# Altium schematic sheet MCU.schdoc(MCU)
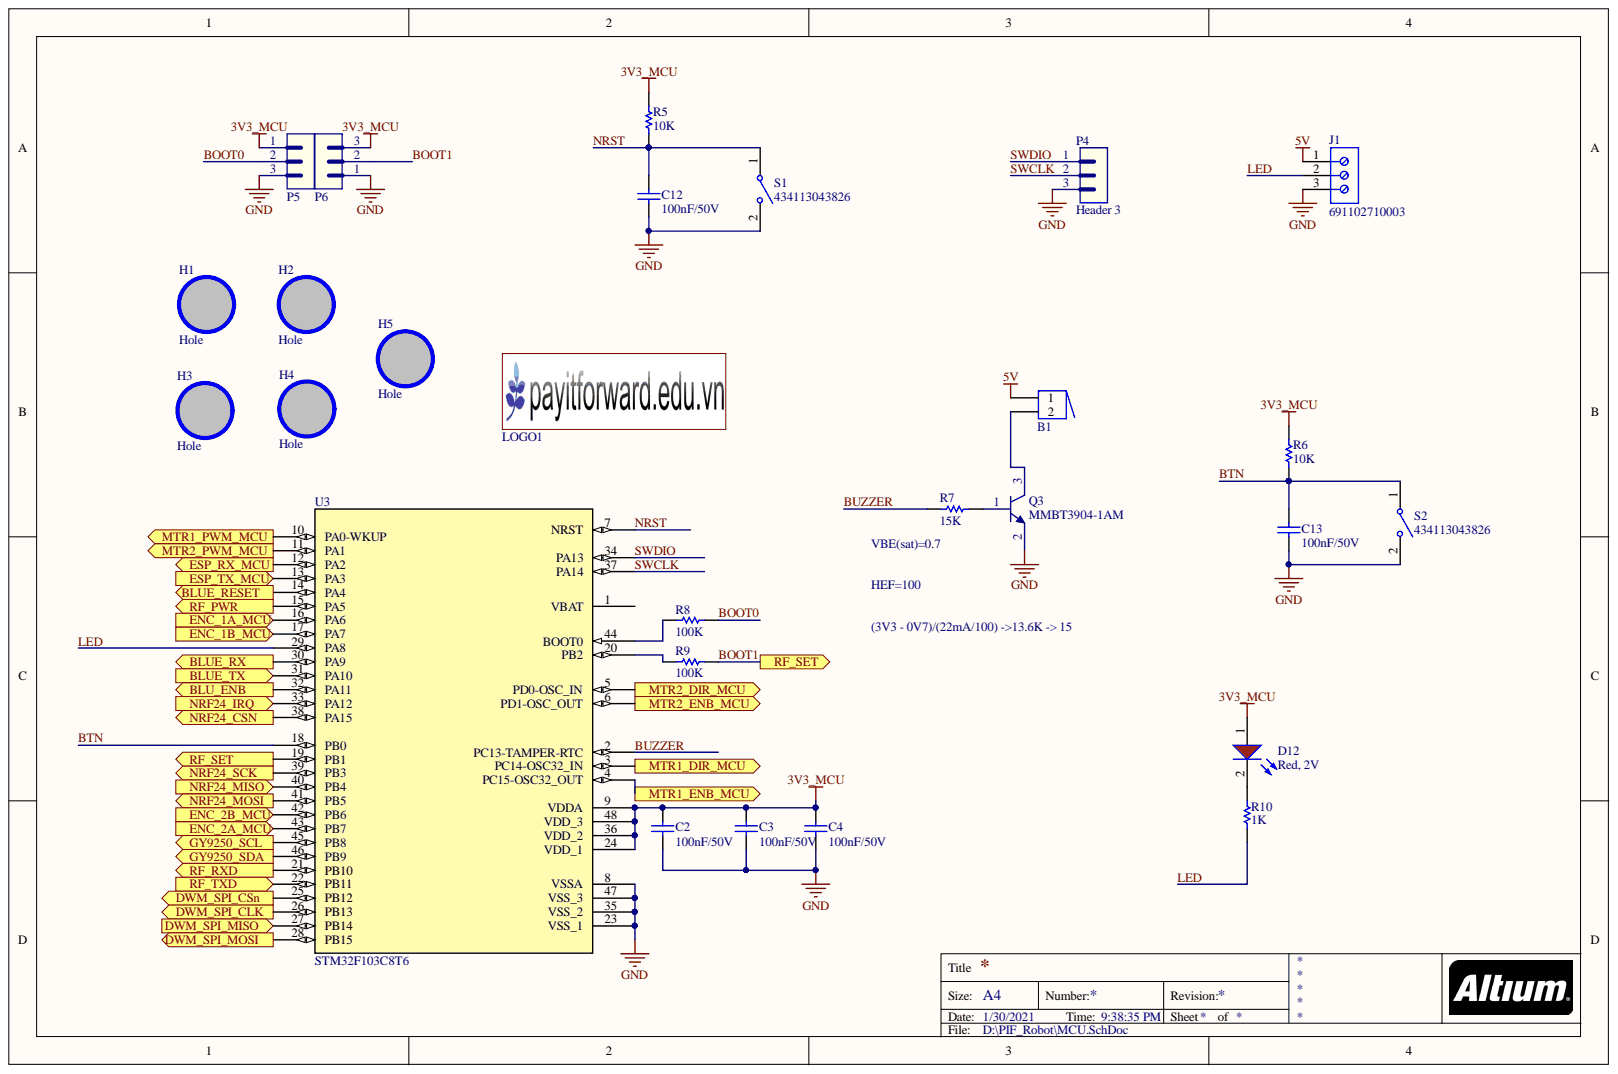
[diagram p0: MCU.schdoc]

## Parts
B1  Buzzer  [BUZZER]  (value not drawn)
C2  value 100nF/50V  [CAP-SM-0805]  (hidden comment: Cap-100nF-50V-0805)
C3  value 100nF/50V  [CAP-SM-0805]  (hidden comment: Cap-100nF-50V-0805)
C4  value 100nF/50V  [CAP-SM-0805]  (hidden comment: Cap-100nF-50V-0805)
C12  value 100nF/50V  [CAP-SM-0805]  (hidden comment: Cap-100nF-50V-0805)
C13  value 100nF/50V  [CAP-SM-0805]  (hidden comment: Cap-100nF-50V-0805)
D12  value Red, 2V  [LED-3mm]  (hidden comment: LED-RED-TH-3mm)
H1  Hole  [LO KHOAN 3MM]
H2  Hole  [LO KHOAN 3MM]
H3  Hole  [LO KHOAN 3MM]
H4  Hole  [LO KHOAN 3MM]
H5  Hole  [LO KHOAN 3MM]
J1  691102710003  [691102710003]
LOGO1  LOGO PIF  [PIF LOGO BIG SSTOP]  (value not drawn)
P4  Header 3  [JP3]
P5  Header 3  [JP3]  (value not drawn)
P6  Header 3  [JP3]  (value not drawn)
Q3  MMBT3904-1AM  [SOT23_NEX]
R5  value 10K  [RES-SM-0805]  (hidden comment: Res-10k-0805)
R6  value 10K  [RES-SM-0805]  (hidden comment: Res-10k-0805)
R7  value 15K  [RES-SM-0805]  (hidden comment: Res-15K-0805)
R8  value 100K  [RES-SM-0805]  (hidden comment: Res-100K-0805)
R9  value 100K  [RES-SM-0805]  (hidden comment: Res-100K-0805)
R10  value 1K  [RES-SM-0805]  (hidden comment: Res-1K-0805)
S1  434113043826  [434113043826]
S2  434113043826  [434113043826]
U3  STM32F103C8T6  [LQFP-48]

## Ports
BLU_ENB, BLUE_RESET, BLUE_RX, BLUE_TX, DWM_SPI_CLK, DWM_SPI_CSn, DWM_SPI_MISO, DWM_SPI_MOSI, ENC_1A_MCU, ENC_1B_MCU, ENC_2A_MCU, ENC_2B_MCU, ESP_RX_MCU, ESP_TX_MCU, GY9250_SCL, GY9250_SDA, MTR1_DIR_MCU, MTR1_ENB_MCU, MTR1_PWM_MCU, MTR2_DIR_MCU, MTR2_ENB_MCU, MTR2_PWM_MCU, NRF24_CSN, NRF24_IRQ, NRF24_MISO, NRF24_MOSI, NRF24_SCK, RF_PWR, RF_RXD, RF_SET, RF_TXD

## Nets
3V3_MCU: C2.2 C3.2 C4.2 D12.1 P5.1 P6.3 R5.2 R6.2 U3.9(VDDA) U3.24(VDD_1) U3.36(VDD_2) U3.48(VDD_3)
5V: B1.1 J1.1
GND: C2.1 C3.1 C4.1 C12.1 C13.1 J1.3 P4.3 P5.3 P6.1 Q3.2 S1.2 S2.2 U3.8(VSSA) U3.23(VSS_1) U3.35(VSS_2) U3.47(VSS_3)
BLU_ENB: U3.32(PA11) ; port BLU_ENB
BLUE_RESET: U3.14(PA4) ; port BLUE_RESET
BLUE_RX: U3.30(PA9) ; port BLUE_RX
BLUE_TX: U3.31(PA10) ; port BLUE_TX
BOOT0: P5.2 R8.2
BOOT1: P6.2 R9.2 U3.19(PB1) ; port RF_SET
BTN: C13.2 R6.1 S2.1 U3.18(PB0)
BUZZER: R7.1 U3.2(PC13-TAMPER-RTC)
DWM_SPI_CLK: U3.26(PB13) ; port DWM_SPI_CLK
DWM_SPI_CSn: U3.25(PB12) ; port DWM_SPI_CSn
DWM_SPI_MISO: U3.27(PB14) ; port DWM_SPI_MISO
DWM_SPI_MOSI: U3.28(PB15) ; port DWM_SPI_MOSI
ENC_1A_MCU: U3.16(PA6) ; port ENC_1A_MCU
ENC_1B_MCU: U3.17(PA7) ; port ENC_1B_MCU
ENC_2A_MCU: U3.43(PB7) ; port ENC_2A_MCU
ENC_2B_MCU: U3.42(PB6) ; port ENC_2B_MCU
ESP_RX_MCU: U3.12(PA2) ; port ESP_RX_MCU
ESP_TX_MCU: U3.13(PA3) ; port ESP_TX_MCU
GY9250_SCL: U3.45(PB8) ; port GY9250_SCL
GY9250_SDA: U3.46(PB9) ; port GY9250_SDA
LED: J1.2 R10.1 U3.29(PA8)
MTR1_DIR_MCU: U3.3(PC14-OSC32_IN) ; port MTR1_DIR_MCU
MTR1_ENB_MCU: U3.4(PC15-OSC32_OUT) ; port MTR1_ENB_MCU
MTR1_PWM_MCU: U3.10(PA0-WKUP) ; port MTR1_PWM_MCU
MTR2_DIR_MCU: U3.5(PD0-OSC_IN) ; port MTR2_DIR_MCU
MTR2_ENB_MCU: U3.6(PD1-OSC_OUT) ; port MTR2_ENB_MCU
MTR2_PWM_MCU: U3.11(PA1) ; port MTR2_PWM_MCU
NRF24_CSN: U3.38(PA15) ; port NRF24_CSN
NRF24_IRQ: U3.33(PA12) ; port NRF24_IRQ
NRF24_MISO: U3.40(PB4) ; port NRF24_MISO
NRF24_MOSI: U3.41(PB5) ; port NRF24_MOSI
NRF24_SCK: U3.39(PB3) ; port NRF24_SCK
NRST: C12.2 R5.1 S1.1 U3.7(NRST)
RF_PWR: U3.15(PA5) ; port RF_PWR
RF_RXD: U3.21(PB10) ; port RF_RXD
RF_TXD: U3.22(PB11) ; port RF_TXD
SWCLK: P4.2 U3.37(PA14)
SWDIO: P4.1 U3.34(PA13)
NetB1_2: B1.2 Q3.3
NetD12_2: D12.2 R10.2
NetQ3_1: Q3.1 R7.2
NetR8_1: R8.1 U3.44(BOOT0)
NetR9_1: R9.1 U3.20(PB2)

Not connected: U3 1(VBAT)

## Library data of the parts
C2-C4 C12 C13 = Cap-100nF-50V-0805: CAP CER 0.1UF 50V Y5V 0805; Manufacturer Part Number: CL21F104ZBCNNNC; Manufacturer: Samsung Electro-Mechanics; Supplier 1 Part Number: 1276-1007-1-ND; Supplier 1 Link: https://www.digikey.com/product-detail/en/samsung-electro-mechanics/CL21F104ZBCNNNC/1276-1007-1-ND/3889093; Supplier 1: Digikey; Local Supplier Link: https://thegioiic.com/products/tu-100nf-0-1uf-50v-0805; Local Supplier: thegioiic; Library Name: Capacitor.SchLib; Component Kind: Standard
D12 = LED-RED-TH-3mm: LED RED CLEAR T-1 T/H; Manufacturer Part Number: WP710A10SEC/J3; Manufacturer: Kingbright; Supplier 1 Part Number: 754-1589-ND; Supplier 1 Link: https://www.digikey.com/product-detail/en/kingbright/WP710A10SEC-J3/754-1589-ND/2769815; Supplier 1: Digikey; Local Supplier Link: https://thegioiic.com/products/led-do-3mm-chan-dai; Local Supplier: thegioiic; Library Name: LED.SchLib; Component Kind: Standard
H1-H5 = Hole: Hole 3mm; Library Name: PIF_C16_lib.IntLib; Component Kind: Standard
J1 = 691102710003: WR-TBL Serie 102 Horizontal Entry Modular, Pressure Clamp, pitch 5mm, 3p; Working Voltage [UL]: 250VAC; Rated Current [UL]: 15A; Pin Number: 3; PCB/Cable/Panel: PCB; Modularity: Yes; Compliance: Lead free / RoHS; Type: Horizontal; Published Date: October 2019; Pitch: 5mm; Part Number: 691102710003; Packaging: Box; Operating Temperature: -40 to +105degC; Mounting Technology: THT; Library Name: 691101710002_Altium_WR-TBL_rev19a.IntLib; ComponentLink2URL: https://katalog.we-online.de/em/datasheet/691102710003.pdf; ComponentLink2Description: Datasheet; ComponentLink1URL: http://www.we-online.de; ComponentLink1Description: Manufacturer; Component Kind: Standard; Application: Pressure Clamp; Publisher: Wurth Elektronik
P4-P6 = Header 3: Header, 3-Pin; Library Name: PIF_C16_lib.IntLib; LatestRevisionNote: Re-released for DXP Platform.; LatestRevisionDate: 17-Jul-2002; Component Kind: Standard; Publisher: Altium Limited
R5 R6 = Res-10k-0805: RES 10K OHM 1% 1/4W 0805; Manufacturer Part Number: RNCP0805FTD10K0; Manufacturer: Stackpole Electronics Inc; Supplier 1 Part Number: RNCP0805FTD10K0CT-ND; Supplier 1 Link: https://www.digikey.com/product-detail/en/stackpole-electronics-inc/RNCP0805FTD10K0/RNCP0805FTD10K0CT-ND/2240601; Supplier 1: Digikey; Local Supplier Link: https://thegioiic.com/products/dien-tro-10k-ohm-0805-5; Local Supplier: thegioiic; Library Name: Resistor.SchLib; Component Kind: Standard
R7 = Res-15K-0805: RES 15K OHM 1% 1/4W 0805; Manufacturer Part Number: CRGCQ0805F15K; Manufacturer: TE Connectivity Passive Product; Supplier 1 Part Number: A129763CT-ND; Supplier 1 Link: https://www.digikey.com/product-detail/en/te-connectivity-passive-product/CRGCQ0805F15K/A129763CT-ND/8577595; Supplier 1: Digikey; Local Supplier Link: https://thegioiic.com/products/dien-tro-15k-ohm-0805-1; Local Supplier: thegioiic; Library Name: Resistor.SchLib; Component Kind: Standard
R8 R9 = Res-100K-0805: RES 0 OHM JUMPER 0805; Manufacturer Part Number: WSL080500000ZEA9; Manufacturer: Vishay Dale; Supplier 1 Part Number: 541-3928-1-ND; Supplier 1 Link: https://www.digikey.com/product-detail/en/vishay-dale/WSL080500000ZEA9/541-3928-1-ND/7423223; Supplier 1: Digikey; Local Supplier Link: https://thegioiic.com/products/dien-tro-0-ohm-0805-5; Local Supplier: thegioiic; Library Name: Resistor.SchLib; Component Kind: Standard
R10 = Res-1K-0805: RES 1K OHM 1% 1/4W 0805; Manufacturer Part Number: RNCP0805FTD1K00; Manufacturer: Stackpole Electronics Inc; Supplier 1 Part Number: RNCP0805FTD1K00CT-ND; Supplier 1 Link: https://www.digikey.com/product-detail/en/stackpole-electronics-inc/RNCP0805FTD1K00/RNCP0805FTD1K00CT-ND/2240568; Supplier 1: Digikey; Local Supplier Link: https://thegioiic.com/products/dien-tro-1k-ohm-0805-5; Local Supplier: thegioiic; Library Name: Resistor.SchLib; Component Kind: Standard
S1 S2 = 434113043826: WS-TASV J-Bend SMT Tact Switch 6x3.5mm, height 4.3mm, 260gf; Washable: No; Rated Voltage: 12VDC; Rated Current: 50mA; Terminal Plating: Silver; Terminal Material: Copper Alloy; Published Date: September 2019; Part Number: 434113043826; Packaging: Tape and Reel; Operation Force: 260gf; Operating Temperature: -40 to +85degC; Mounting Technology: SMT; Library Name: 434113043826_Altium_WS-TASV_rev20a.IntLib; Height: 4.3mm; Contact Plating: Silver; Contact Material: Stainless Steel; ComponentLink2URL: https://katalog.we-online.com/em/datasheet/434113043826.pdf; ComponentLink2Description: Datasheet; ComponentLink1URL: http://www.we-online.de; ComponentLink1Description: Manufacturer; Component Kind: Standard; Publisher: Wurth Elektronik
U3 = STM32F103C8T6: No Description Available; Vendor: STMicroelectronics; SourceLibrary: StMicro_2011-06-07; Built_By: EMA_UL_Team; Type: DEV; RefDes: RefDes; Manufacturer_Part_Number: STM32F103C8T6; Component Kind: Standard; Library Name: Bai1.SchLib; Copyright: Copyright (C) 2018 Accelerated Designs. All rights reserved
B1 = Buzzer: Library Name: PIF_Schematic_Lib.SchLib; Component Kind: Standard
LOGO1 = LOGO PIF: Library Name: PIF_C16_lib.IntLib; Component Kind: Standard
Q3 = MMBT3904-1AM: Library Name: BJT.SchLib; Component Kind: Standard
